# Altium schematic sheet EIT_TETRAPOLAR.SchDoc
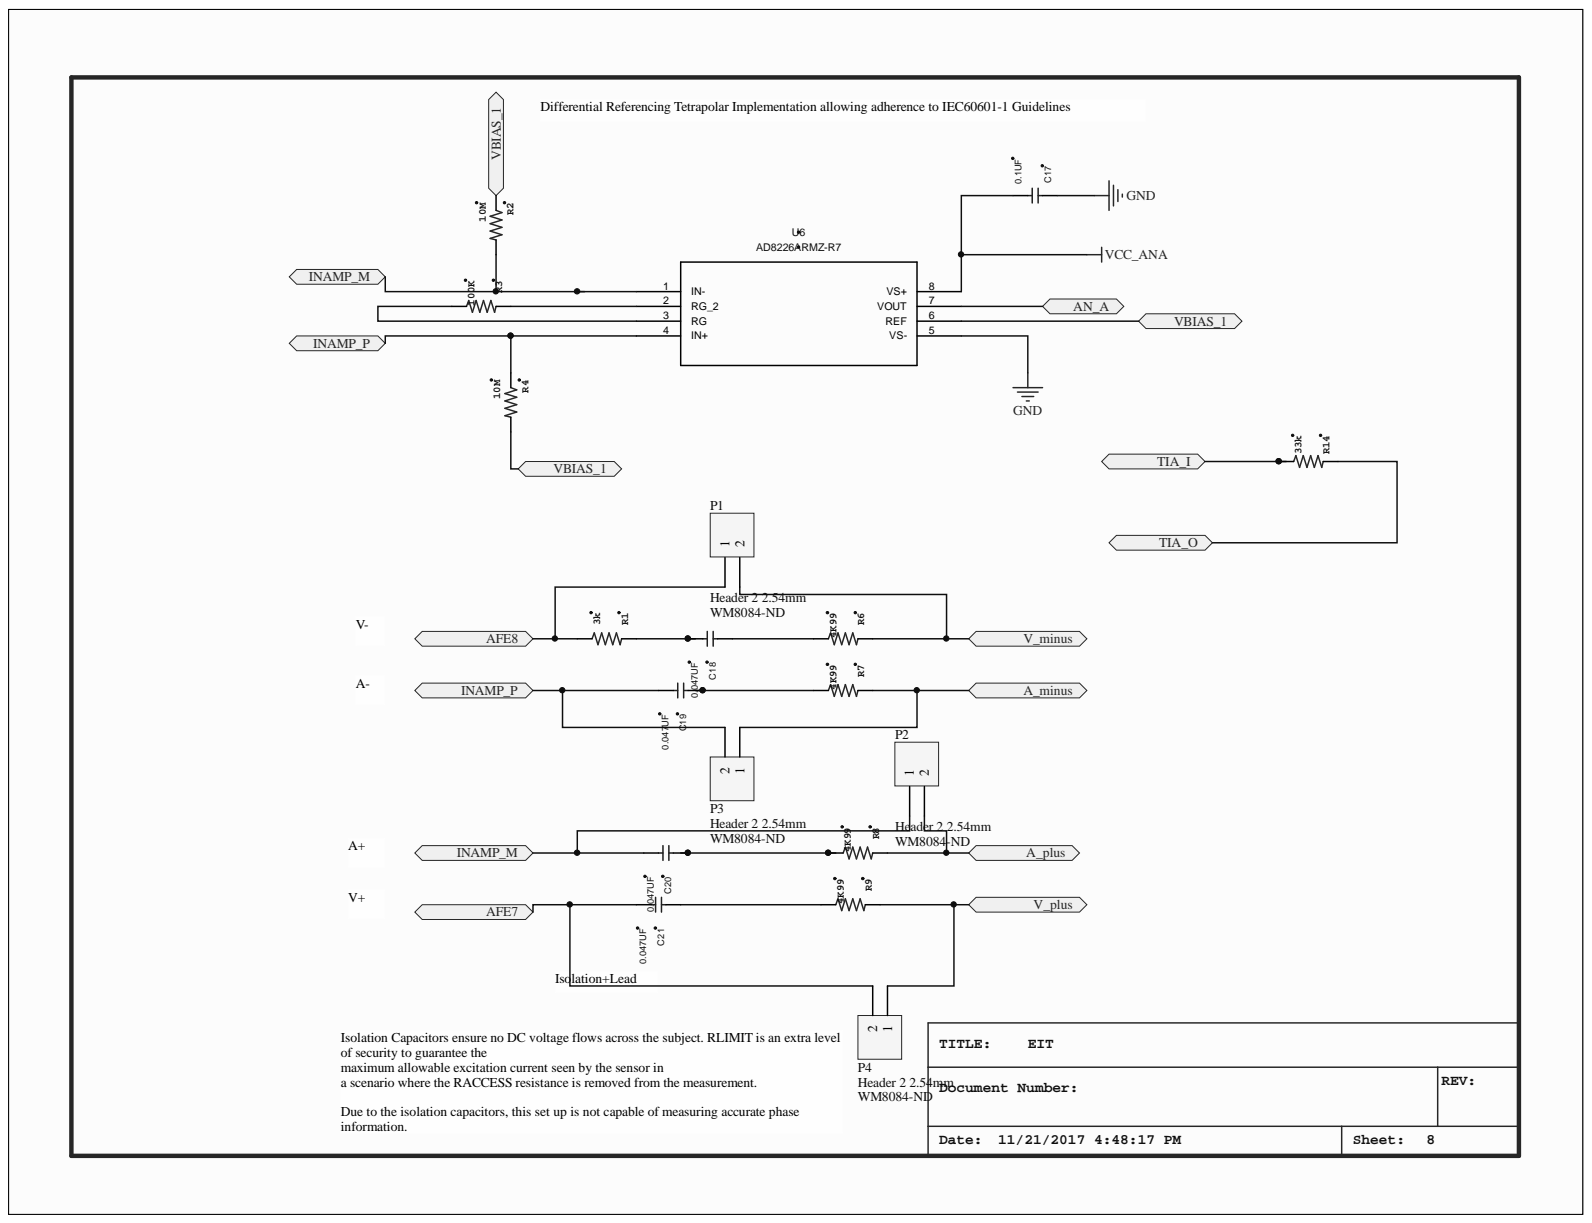
[diagram p0: EIT_TETRAPOLAR.SchDoc]

## Parts
C17  0.1UF  [CAP 0402/1005]
C18  0.047UF  [CAP 0402/1005]
C19  0.047UF  [CAP 0402/1005]
C20  0.047UF  [CAP 0402/1005]
C21  0.047UF  [CAP 0402/1005]
P1  Header 2 2.54mm  [1X02 2.54mm]
P2  Header 2 2.54mm  [1X02 2.54mm]
P3  Header 2 2.54mm  [1X02 2.54mm]
P4  Header 2 2.54mm  [1X02 2.54mm]
R1  3k  [RES_1608X55]
R2  10M  [RES_1608X55]
R3  100K  [RES_1608X55]
R4  10M  [RES_1608X55]
R6  4K99  [RES_1608X55]
R7  4K99  [RES_1608X55]
R8  4K99  [RES_1608X55]
R9  4K99  [RES_1608X55]
R14  33k  [RES_1608X55]
U6  AD8226ARMZ-R7  [AD8226-ARMZ-R7]

## Ports
A_MINUS, A_PLUS, AFE7, AFE8, AN_A, INAMP_M, INAMP_P, TIA_I, TIA_O, V_MINUS, V_PLUS, VBIAS_1

## Nets
GND: C17.2 U6.5(VS-)
VCC_ANA: C17.1 U6.8(VS+)
AFE7: C21.1 P4.2 ; port AFE7
AFE8: P1.1 R1.2 ; port AFE8
AN_A: U6.7(VOUT) ; port AN_A
INAMP_M: C20.1 P2.1 R2.1 U6.1(IN-) ; port INAMP_M
INAMP_P: C19.1 P3.2 R4.2 U6.4(IN+) ; port INAMP_P
TIA_I: R14.2 ; port TIA_I
TIA_O: R14.1 ; port TIA_O
VBIAS_1: R2.2 R4.1 U6.6(REF) ; port VBIAS_1
NetC18_1: C18.1 R1.1
NetC18_2: C18.2 R6.2
NetC19_2: C19.2 R7.2
NetC20_2: C20.2 R8.2
NetC21_2: C21.2 R9.2
NetP1_2: P1.2 R6.1 ; port V_MINUS
NetP2_2: P2.2 R8.1 ; port A_PLUS
NetP3_1: P3.1 R7.1 ; port A_MINUS
NetP4_1: P4.1 R9.1 ; port V_PLUS
NetR3_1: R3.1 U6.2(RG_2)
NetR3_2: R3.2 U6.3(RG)

## Library data of the parts
C17 = CAP_0402_0.1UF: CAP CER 0.1UF 4V X5R 0402 10% tolerance; Manufacturer 1: KEMET; Manufacturer Part Number 1: C0402C104K7PAC7867; Vendor: DIGIKEY; Component Kind: Standard; Supplier 1: Digi-Key; Supplier Part Number 1: 399-14871-1-ND; Value: 0.1UF; Library Name: EIT_lib.SchLib
C18-C21 = CAP_0402_0.047UF: CAP CER 0.047UF 4V X5R 0402; Manufacturer 1: MURATA ELECTRONICS NORTH AMERICA; Manufacturer Part Number 1: GRM155R71E473KA88D; Vendor: DIGIKEY; Component Kind: Standard; Supplier 1: Digi-Key; Supplier Part Number 1: 490-3254-1-ND; Value: 0.047UF; Library Name: EIT_lib.SchLib
P1-P4 = Header 2 2.54mm: Header, 2-Pin 2.54mm; Component Kind: Standard; LatestRevisionDate: 17-Jul-2002; LatestRevisionNote: Re-released for DXP Platform.; Supplier 1: Digi-Key; Supplier Part Number 1: WM8084-ND; Library Name: EIT_lib.IntLib; Publisher: Altium Limited
R1 = RES_0603_3k: 3kOhm RES_0603; Manufacturer 1: Panasonic Electronic Components; Manufacturer Part Number 1: ERA-3AEB302V; PARTNO: MCR03ERTF5600; DeviceName: -0603(1608METRIC); DeviceSetName: RESISTOR-US; GateName_1: G$1; Component Kind: Standard; OC_NEWARK: 52K8621; Supplier 1: Digi-Key; Supplier Part Number 1: P3.0KDBDKR-ND; SymbolName_1: RESISTOR-US; Value: 3k; Library Name: EIT_lib.SchLib; LibraryName: BiomedicalImagingLibrary
R2 R4 = RES_0603_10M: 10MOhm RES_0603; Manufacturer 1: Yageo; Manufacturer Part Number 1: RC0603JR-0710ML; PARTNO: MCR03ERTF5600; DeviceName: -0603(1608METRIC); DeviceSetName: RESISTOR-US; GateName_1: G$1; Component Kind: Standard; OC_NEWARK: 52K8621; Supplier 1: Digi-Key; Supplier Part Number 1: 541-10MAYCT-ND; SymbolName_1: RESISTOR-US; Value: 10M; Library Name: EIT_lib.SchLib; LibraryName: BiomedicalImagingLibrary
R3 = RES_0603_100K: 100kOhm RES_0603; Manufacturer 1: VISHAY/DALE; Manufacturer Part Number 1: TNPW0603100KBEEA; DeviceName: -0603(1608METRIC); DeviceSetName: RESISTOR-US; GateName_1: G$1; Component Kind: Standard; Supplier 1: Digi-Key; Supplier Part Number 1: TNP100KAADKR-ND; SymbolName_1: RESISTOR-US; Value: 100K; Library Name: EIT_lib.SchLib
R6-R9 = RES_0603_4K99: 4k99Ohm RES_0603; Manufacturer 1: VISHAY/DALE; Manufacturer Part Number 1: TNPW06034K99BEEA; PARTNO: MCR03ERTF5600; DeviceName: -0603(1608METRIC); DeviceSetName: RESISTOR-US; GateName_1: G$1; Component Kind: Standard; OC_NEWARK: 52K8621; Supplier 1: Digi-Key; Supplier Part Number 1: TNP4.99KAADKR-ND; SymbolName_1: RESISTOR-US; Value: 4K99; Library Name: EIT_lib.SchLib; LibraryName: BiomedicalImagingLibrary
R14 = RES_0603_33k: 33kOhm RES_0603; Manufacturer 1: Panasonic Electronic Components; Manufacturer Part Number 1: ERA-3AEB333V; PARTNO: MCR03ERTF5600; DeviceName: -0603(1608METRIC); DeviceSetName: RESISTOR-US; GateName_1: G$1; Component Kind: Standard; OC_NEWARK: 52K8621; Supplier 1: Digi-Key; Supplier Part Number 1: P33KDBCT-ND; SymbolName_1: RESISTOR-US; Value: 33k; Library Name: EIT_lib.SchLib; LibraryName: BiomedicalImagingLibrary
U6 = AD8226ARMZ-R7: Instrumentation Amplifier 1 Circuit Rail-to-Rail 8-SOIC; Manufacturer 1: Analog Devices Inc; Built_By: EMA_UL_Team; C: Copyright (C) 2015 Accelerated Designs. All rights reserved; Component Kind: Standard; Manufacturer Part Number 1: AD8226ARMZ-R7; Supplier 1: Newark; Supplier 2: Digi-Key; Supplier Part Number 1: 80R8168; Supplier Part Number 2: AD8226ARMZ-R7DKR-ND; Value: AD8226ARMZ-R7; Library Name: EIT_lib.SchLib
